# Altium schematic sheet Power_and_USB.SchDoc
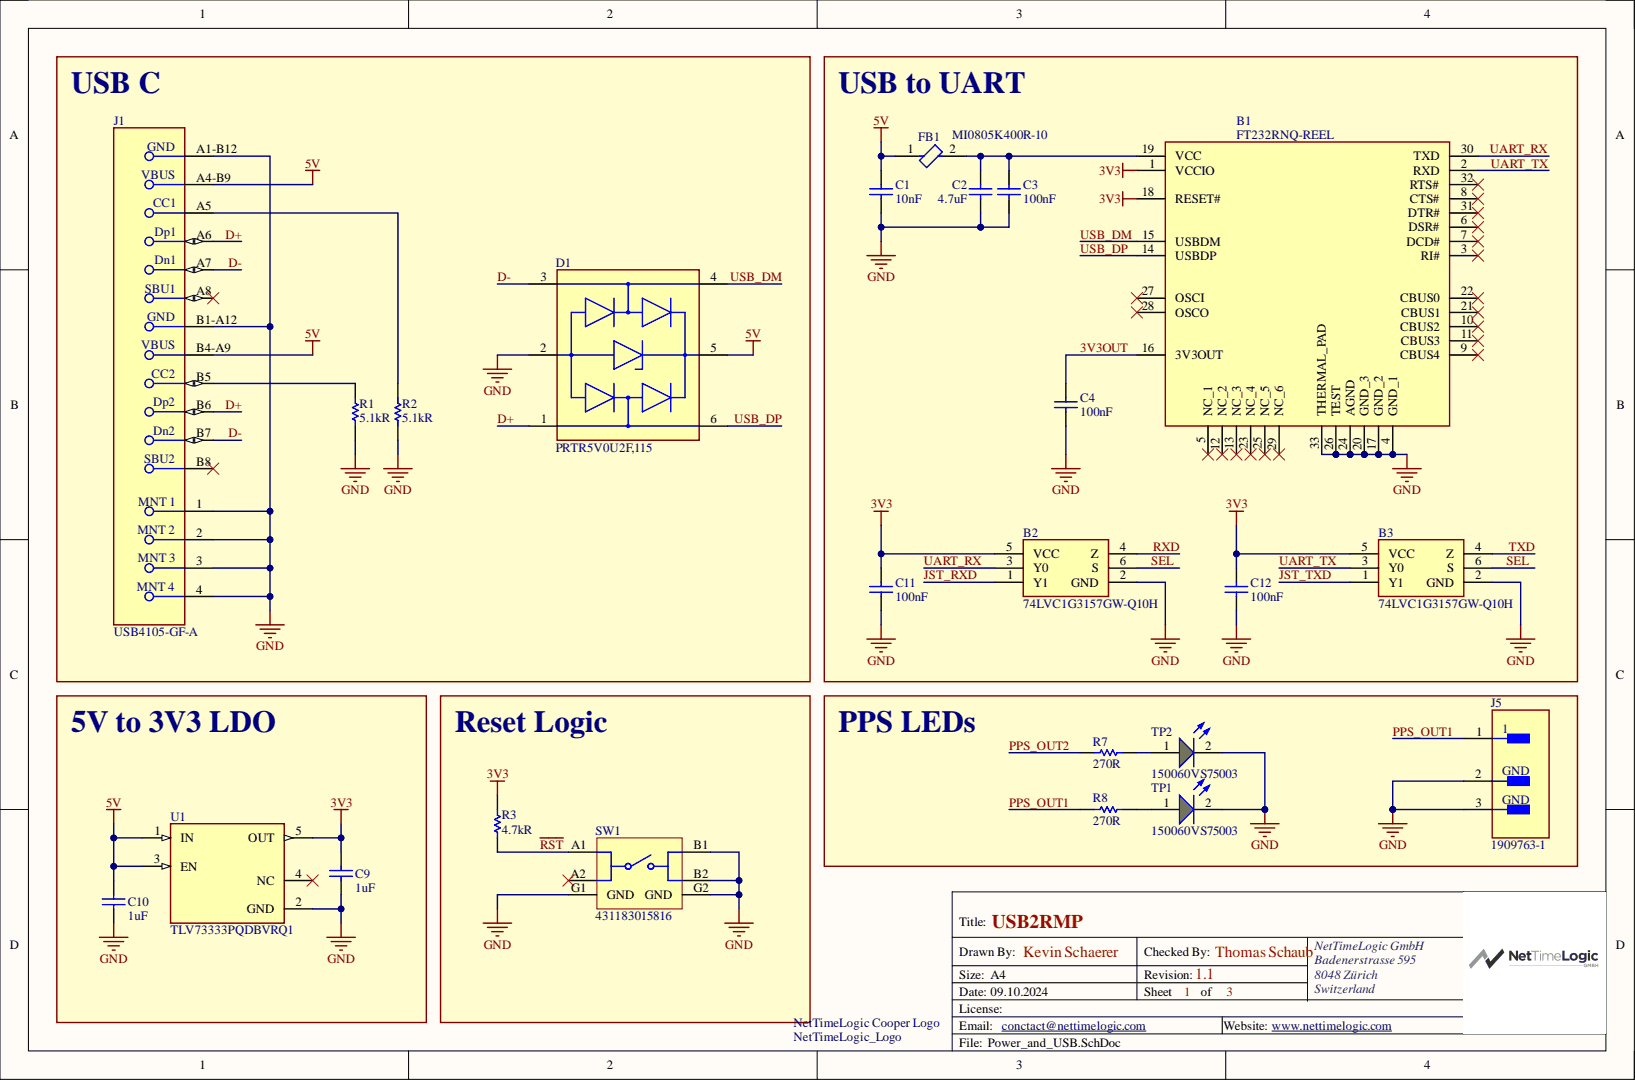
[diagram p0: Power_and_USB.SchDoc]

## Parts
1  NetTimeLogic_Logo  [NetTimeLogic_Logo_25mm]  (no BOM)
B1  FT232RNQ-REEL  [QFN50P500X500X90-33N-D]
B2  74LVC1G3157GW-Q10H  [SOT65P210X110-6N]
B3  74LVC1G3157GW-Q10H  [SOT65P210X110-6N]
C1  value 10nF  [FP-C0603C-CF-MFG]  (hidden comment: C0603C103K5RACTU)
C2  value 4.7uF  [CAPC1608X90X35LL15T15]  (hidden comment: C0603C475M9PACTU)
C3  value 100nF  [FP-C0603C-CF-MFG]  (hidden comment: C0603C104K4RACTU)
C4  value 100nF  [FP-C0603C-CF-MFG]  (hidden comment: C0603C104K4RACTU)
C9  value 1uF  [CAPC1608X90X35LL15T15]  (hidden comment: C0603C105K4RACTU)
C10  value 1uF  [CAPC1608X90X35LL15T15]  (hidden comment: C0603C105K4RACTU)
C11  value 100nF  [FP-C0603C-CF-MFG]  (hidden comment: C0603C104K4RACTU)
C12  value 100nF  [FP-C0603C-CF-MFG]  (hidden comment: C0603C104K4RACTU)
D1  PRTR5V0U2F,115  [FP-SOT886-MFG]
FB1  MI0805K400R-10  [FP-MI0805-MFG]
J1  USB4105-GF-A  [FP-USB4105-GF-A-MFG]
J5  1909763-1  [FP-1909763-1-MFG]
R1  value 5.1kR  [RESC1608X55X25LL10T15]  (hidden comment: RC0603FR-075K1L)
R2  value 5.1kR  [RESC1608X55X25LL10T15]  (hidden comment: RC0603FR-075K1L)
R3  value 4.7kR  [RESC1608X55X25LL10T15]  (hidden comment: RC0603FR-074K7L)
R7  value 270R  [RESC1608X55X25LL10T15]  (hidden comment: RC0603FR-07270RL)
R8  value 270R  [RESC1608X55X25LL10T15]  (hidden comment: RC0603FR-07270RL)
SW1  431183015816  [WS-TASV_L5W5H1.5_4311x30158x6]
TP1  150060VS75003  [WL-SMCW_0603_150060xx75003]
TP2  150060VS75003  [WL-SMCW_0603_150060xx75003]
U1  TLV73333PQDBVRQ1  [FP-DBV0005A-MFG]

## Nets
3V3: B1.1(VCCIO) B1.18(RESET#) B2.5(VCC) B3.5(VCC) C9.2 C11.2 C12.2 R3.1 U1.5(OUT)
5V: C1.2 C10.2 D1.5 FB1.1 J1.A4-B9 J1.B4-A9 U1.1(IN) U1.3(EN)
GND: B1.4(GND_1) B1.17(GND_2) B1.20(GND_3) B1.24(AGND) B1.26(TEST) B1.33(THERMAL_PAD) B2.2(GND) B3.2(GND) C1.1 C2.1 C3.1 C4.1 C9.1 C10.1 C11.1 C12.1 D1.2 J1.1 J1.2 J1.3 J1.4 J1.A1-B12 J1.B1-A12 J5.2 J5.3 R1.1 R2.1 SW1.B1 SW1.B2 SW1.G1(GND) SW1.G2(GND) TP1.2 TP2.2 U1.2(GND)
3V3OUT: B1.16(3V3OUT) C4.2
~{RST}: R3.2 SW1.A1
D+: D1.1 J1.A6 J1.B6
D-: D1.3 J1.A7 J1.B7
JST_RXD: B2.1(Y1)
JST_TXD: B3.1(Y1)
PPS_OUT1: J5.1 R8.1
PPS_OUT2: R7.1
RXD: B2.4(Z)
SEL: B2.6(S) B3.6(S)
TXD: B3.4(Z)
UART_RX: B1.30(TXD) B2.3(Y0)
UART_TX: B1.2(RXD) B3.3(Y0)
USB_DM: B1.15(USBDM) D1.4
USB_DP: B1.14(USBDP) D1.6
NetB1_19: B1.19(VCC) C2.2 C3.2 FB1.2
NetJ1_A5: J1.A5 R2.2
NetJ1_B5: J1.B5 R1.2
NetR7_2: R7.2 TP2.1
NetR8_2: R8.2 TP1.1

Not connected: B1 3(RI#) 5(NC_1) 6(DSR#) 7(DCD#) 8(CTS#) 9(CBUS4) 10(CBUS2) 11(CBUS3) 12(NC_2) 13(NC_3) 21(CBUS1) 22(CBUS0) 23(NC_4) 25(NC_5) 27(OSCI) 28(OSCO) 29(NC_6) 31(DTR#) 32(RTS#); J1 A8 B8; SW1 A2; U1 4(NC)

## Library data of the parts
1 = CMP-00016-12: NetTimeLogic Cooper Logos 15mm,  25mm, 35mm, 50 mm; Library Name: NetTimeLogic GmbH
B1 = CMP-183-000000-5: UART Interface IC USB Full Speed to Serial UART IC, Includes Oscillator and EEPROM, QFN-32; Manufacturer_Name: FTDI Chip; Geometry.Height: 0.9mm; Component Kind: Standard; Datasheet Link: https://www.mouser.de/datasheet/2/163/DS_FT232RN-3011324.pdf; Design Item ID: FT232RNQ-REEL; Manufacturer_Part_Number: FT232RNQ-REEL; Mouser Part Number: 895-FT232RNQ-REEL; Mouser Price/Stock: https://www.mouser.co.uk/ProductDetail/FTDI/FT232RNQ-REEL?qs=By6Nw2ByBD2sZBqAeYrE8Q%3D%3D; Source: FT232RNQ-REEL.SchLib; Library Name: NetTimeLogic GmbH
B2 B3 = CMP-193-000000-4: 74LVC1G3157-Q100 - 2-channel analog multiplexer/demultiplexer@en-us; Manufacturer_Name: Nexperia; Geometry.Height: 1.1mm; Component Kind: Standard; Datasheet Link: https://assets.nexperia.com/documents/data-sheet/74LVC1G3157_Q100.pdf; Design Item ID: 74LVC1G3157GW-Q10H; Manufacturer_Part_Number: 74LVC1G3157GW-Q10H; Mouser Part Number: 771-74LVC1G3157GWQ10; Mouser Price/Stock: https://www.mouser.co.uk/ProductDetail/Nexperia/74LVC1G3157GW-Q10H?qs=5XOdhvmYM0OEi4hrMRn80A%3D%3D; Source: 74LVC1G3157GW-Q10H.SchLib; Library Name: NetTimeLogic GmbH
C1 = CMP-00068-12: CAP CER 10000PF 50V X7R 0603; Dissipation Factor: 2.5%; Tolerance: 10%; Case Code (Imperial): 0603; Case Code (Metric): 1608; Case/Package: 0603; Component Kind: Standard; Construction: Flat; Depth: 0.8mm; Dielectric: X7R; Height: 0.8mm; Lead Pitch: 0.7mm; Length: 1.6mm; Max Operating Temperature: 125°C; Min Operating Temperature: -55°C; Mounting Technology: PCB,Surface Mount; Package Quantity: 4000; Packaging: Tape and Reel; Pins: 2; Radiation Hardening: No; Reach SVHC Compliant: No; RoHS Compliant: Yes; Series: C0603C; Termination: SMD/SMT; Voltage: 50V; Voltage Rating: 50V; Voltage Rating (DC): 50V; Width: 0.8mm; Library Name: NetTimeLogic GmbH
C2 = CMP-00091-2: Cap Ceramic 4.7uF 6.3V X5R 20% SMD 0603 85C Paper T/R; Dissipation Factor: 5%; Case Code (Imperial): 0603; Case Code (Metric): 1608; Case/Package: 0603; Component Kind: Standard; Construction: Flat; Depth: 0.8mm; Dielectric: X5R; Dielectric Material: Ceramic; Height: 0.8mm; Lead Free: Lead Free; Lead Pitch: 0.7mm; Length: 1.6mm; Max Operating Temperature: 85°C; Min Operating Temperature: -55°C; Mounting Technology: Surface Mount; Package Quantity: 4000; Packaging: Tape and Reel; Radiation Hardening: No; Reach SVHC Compliant: No; RoHS Compliant: Yes; Series: SMD Comm X5R; Termination: SMD/SMT; Thickness: 0.034inch; Tolerance: 20%; Voltage Rating: 6.3V; Voltage Rating (DC): 6.3V; Width: 0.8mm; Library Name: NetTimeLogic GmbH
C3 C4 C11 C12 = CMP-00009-15: Cap Ceramic 0.1uF 16V X7R 10% SMD 0603 125°C Paper T/R; Dissipation Factor: 3.5%; Case Code (Imperial): 0603; Case Code (Metric): 1608; Case/Package: 0603; Component Kind: Standard; Construction: Flat; Contact Plating: Tin,Matte; Depth: 0.8mm; Dielectric: X7R; Dielectric Material: Ceramic; Height: 0.8mm; Lead Pitch: 0.7mm; Length: 1.6mm; Max Operating Temperature: 125°C; Min Operating Temperature: -55°C; Mounting Technology: Surface Mount,PCB; Package Quantity: 4000; Packaging: Tape and Reel; Pins: 2; Radiation Hardening: No; Reach SVHC Compliant: Yes; Series: SMD Comm X7R; Termination: SMD/SMT; Thickness: 0.034inch; Tolerance: 10%; Voltage: 16V; Voltage Rating: 16V; Voltage Rating (DC): 16V; Weight: 7.1E-05oz; Width: 0.8mm; Library Name: NetTimeLogic GmbH
C9 C10 = CMP-00012-8: Cap Ceramic 1uF 16V X7R 10% SMD 0603 125°C Paper T/R; Dissipation Factor: 3.5%; Case Code (Imperial): 0603; Case Code (Metric): 1608; Case/Package: 0603; Component Kind: Standard; Construction: Flat; Contact Plating: Tin,Matte; Depth: 0.8mm; Dielectric: X7R; Dielectric Material: Ceramic; Height: 0.8mm; Length: 1.6mm; Max Operating Temperature: 125°C; Min Operating Temperature: -55°C; Mounting Technology: Surface Mount,PCB; Package Quantity: 4000; Packaging: Tape and Reel; Pins: 2; Radiation Hardening: No; Reach SVHC Compliant: Yes; RoHS Compliant: Yes; Series: SMD Comm X7R; Termination: SMD/SMT; Thickness: 0.034inch; Tolerance: 10%; Voltage: 16V; Voltage Rating: 16V; Voltage Rating (DC): 16V; Width: 0.8mm; Library Name: NetTimeLogic GmbH
D1 = CMP-00051-7: TVS DIODE 5.5VWM SOT886; Component Kind: Standard; RoHS Compliant: Yes; Library Name: NetTimeLogic GmbH
FB1 = CMP-00154-2: Ferrite Chip, 1 Function(s), 1.5A, 2 Pin(s); Channels: 1; DC Resistance-Max: 50mR; Output Impedance: 40R; Component Kind: Standard; Current Rating: 1.5A; Filter Type: FERRITE CHIP; Height: 0.9mm; JESD-609 Code: e3; Length: 2mm; Manufacturer Series: MI0805K400R; Max Operating Temperature: 125°C; Min Operating Temperature: -55°C; Mounting Technology: Surface Mount; Packaging: Tape and Reel; RoHS Compliant: Yes; Terminal Finish: Tin; Width: 1.25mm; Library Name: NetTimeLogic GmbH
J1 = CMP-002-00010-4: Conn USB 2.0 Type C RCP 24 POS 0.3mm/0.5mm Solder RA SMD 24 Terminal 1 Port T/R; Component Kind: Standard; Library Name: NetTimeLogic GmbH
J5 = CMP-002-00011-2: RF COAX Connector, UMCC PLUG, 50 OHM, PCB; Co; Connector Type: UMCC Coaxial; Body Style: Straight; Component Kind: Standard; Library Name: NetTimeLogic GmbH
R1 R2 = CMP-009-00031-1: SMD Chip Resistor, 5.1 kOhm, ± 1%, 100 mW, 0603 [1608 Metric], Thick Film, General Purpose; Max Power Dissipation: 100mW; Temperature Coefficient: 100ppm/°C; Voltage Rating: 50V; Case Code (Imperial): 0603; Case Code (Metric): 1608; Case/Package: 0603; Component Kind: Standard; Construction: Rectangular; Depth: 0.8mm; Features: Moisture Resistant; Height: 0.022inch; Lead Free: Lead Free; Lead Pitch: 0.3mm; Length: 0.063inch; Max Operating Temperature: 155°C; Military Standard: Not; Min Operating Temperature: -55°C; Number of Terminations: 2; Package Quantity: 1; Packaging: Tape and Reel; Pins: 2; Power Rating: 100mW; Reach SVHC Compliant: No; Resistance Tolerance: 1%; Resistor Type: Thick Film; RoHS Compliant: Yes; Series: RC; Termination: SMD/SMT; Tolerance: 1%; Voltage Rating (DC): 50V; Width: 0.031inch; Library Name: NetTimeLogic GmbH
R3 = CMP-00024-6: Res Thick Film 0603 4.7K Ohm 1% 1/10W ±100ppm/°C Molded SMD Paper T/R; Max Power Dissipation: 100mW; Voltage Rating: 50V; Case Code (Imperial): 0603; Case Code (Metric): 1608; Case/Package: 0603; Component Kind: Standard; Construction: Rectangular; Depth: 0.8mm; Features: Moisture Resistant; Height: 0.45mm; Lead Free: Lead Free; Lead Pitch: 0.3mm; Length: 1.6mm; Max Operating Temperature: 155°C; Military Standard: Not; Min Operating Temperature: -55°C; Number of Terminations: 2; Packaging: Tape and Reel; Pins: 2; Power Rating: 100mW; Resistance Tolerance: 1%; Resistor Type: Thick Film; RoHS Compliant: Yes; Series: RC; Temperature Coefficient: 100ppm/°C; Termination: SMD/SMT; Tolerance: 1%; Voltage Rating (DC): 50V; Width: 0.8mm; Library Name: NetTimeLogic GmbH
R7 R8 = CMP-009-00018-6: SMD Chip Resistor, 270 Ohm, ± 1%, 100 mW, 0603 [1608 Metric], Thick Film, General Purpose; Max Power Dissipation: 100mW; Temperature Coefficient: 100ppm/°C; Voltage Rating: 50V; Case Code (Imperial): 0603; Case Code (Metric): 1608; Case/Package: 0603; Component Kind: Standard; Construction: Rectangular; Depth: 0.8mm; Features: Moisture Resistant; Height: 0.022inch; Lead Free: Lead Free; Lead Pitch: 0.3mm; Length: 0.063inch; Max Operating Temperature: 155°C; Military Standard: Not; Min Operating Temperature: -55°C; Number of Terminations: 2; Package Quantity: 5000; Packaging: Tape and Reel; Pins: 2; Power Rating: 100mW; Reach SVHC Compliant: Unknown; Resistance Tolerance: 1%; Resistor Type: Thick Film; RoHS Compliant: Yes; Series: RC; Technology: THICK FILM; Termination: SMD/SMT; Tolerance: 1%; Voltage Rating (DC): 50V; Weight: 7.1E-05oz; Width: 0.031inch; Library Name: NetTimeLogic GmbH
SW1 = CMP-00161-1: Switch Tactile Spst-no 0.02A 15V; Actuator Length: 0.3mm; Actuator Material: Polymer,Liquid Crystal Polymer; Actuator Type: Standard; Contact Current Rating: 20mA; Contact Resistance: 100mR; Current Rating: 50mA; Illumination Type: Non-Illuminated,Illuminated; Mechanical Life: 1000000Cycles; Operating Force: 160gf; Switch Function: Off-Mom; Switch Travel: 0.25mm; Throw Configuration: SPST; Component Kind: Standard; Contact Material: Stainless Steel,Steel; Contact Type: 1 maker; Height: 1.5mm; Insulation Resistance: 100MR; Length: 5mm; Max Operating Temperature: 85°C; Min Operating Temperature: -40°C; Mounting Technology: Surface Mount; Packaging: Cut Tape; Reach SVHC Compliant: No; RoHS Compliant: Yes; Series: WS-TASV; Termination: Solder; Width: 5mm; Library Name: NetTimeLogic GmbH
TP1 TP2 = CMP-00137-8: Led, Bright Green, 100Mcd, 572Nm Rohs Compliant: Yes; Forward Current: 20mA; Forward Voltage: 2V; Case Code (Imperial): 0603; Case Code (Metric): 1608; Case/Package: 0603; Color: Green; Component Kind: Standard; Component Type: LED; Height: 0.7mm; Max Operating Temperature: 100°C; Min Operating Temperature: -40°C; Mounting Technology: Surface Mount; Pins: 2; Library Name: NetTimeLogic GmbH
U1 = CMP-181-000003-1: Automotive 300-mA, low-IQ, low-dropout voltage regulator with enable 5-SOT-23 -40 to 125; Component Kind: Standard; Library Name: NetTimeLogic GmbH
